# Altium schematic sheet imx6ull.SchDoc
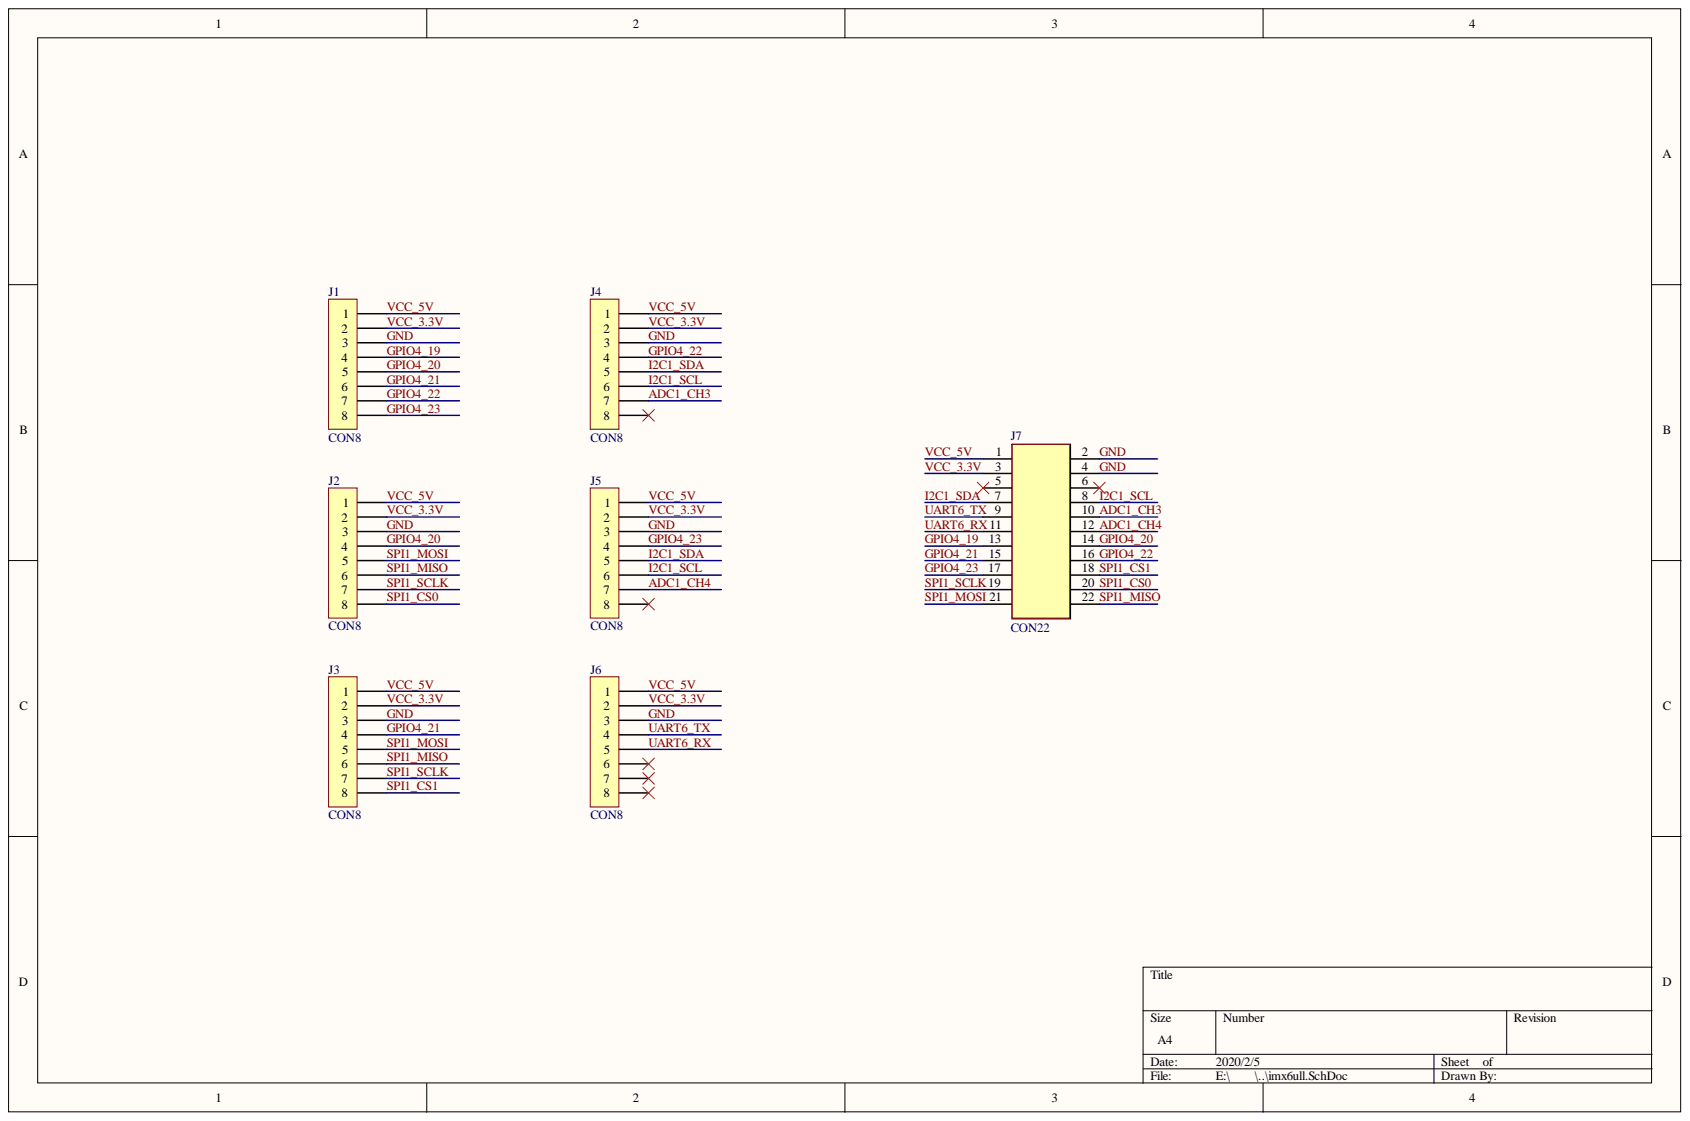
[diagram p0: imx6ull.SchDoc]

## Parts
J1  CON8  [hdr1x8]
J2  CON8  [hdr1x8]
J3  CON8  [hdr1x8]
J4  CON8  [hdr1x8]
J5  CON8  [hdr1x8]
J6  CON8  [hdr1x8]
J7  CON22  [ph2x11_2a00]

## Nets
ADC1_CH3: J4.7 J7.10
ADC1_CH4: J5.7 J7.12
GND: J1.3 J2.3 J3.3 J4.3 J5.3 J6.3 J7.2 J7.4
GPIO4_19: J1.4 J7.13
GPIO4_20: J1.5 J2.4 J7.14
GPIO4_21: J1.6 J3.4 J7.15
GPIO4_22: J1.7 J4.4 J7.16
GPIO4_23: J1.8 J5.4 J7.17
I2C1_SCL: J4.6 J5.6 J7.8
I2C1_SDA: J4.5 J5.5 J7.7
SPI1_CS0: J2.8 J7.20
SPI1_CS1: J3.8 J7.18
SPI1_MISO: J2.6 J3.6 J7.22
SPI1_MOSI: J2.5 J3.5 J7.21
SPI1_SCLK: J2.7 J3.7 J7.19
UART6_RX: J6.5 J7.11
UART6_TX: J6.4 J7.9
VCC_3.3V: J1.2 J2.2 J3.2 J4.2 J5.2 J6.2 J7.3
VCC_5V: J1.1 J2.1 J3.1 J4.1 J5.1 J6.1 J7.1

Not connected: J4 8; J5 8; J6 6 7 8; J7 5 6

## Library data of the parts
J7 = Component_1: Component Kind: Standard; Library Name: Schlib1.SchLib
J1-J6 = CON8: Component Kind: Standard; Library Name: 常用元件库.SCHLIB
